# Altium schematic sheet FlashBoard.SchDoc
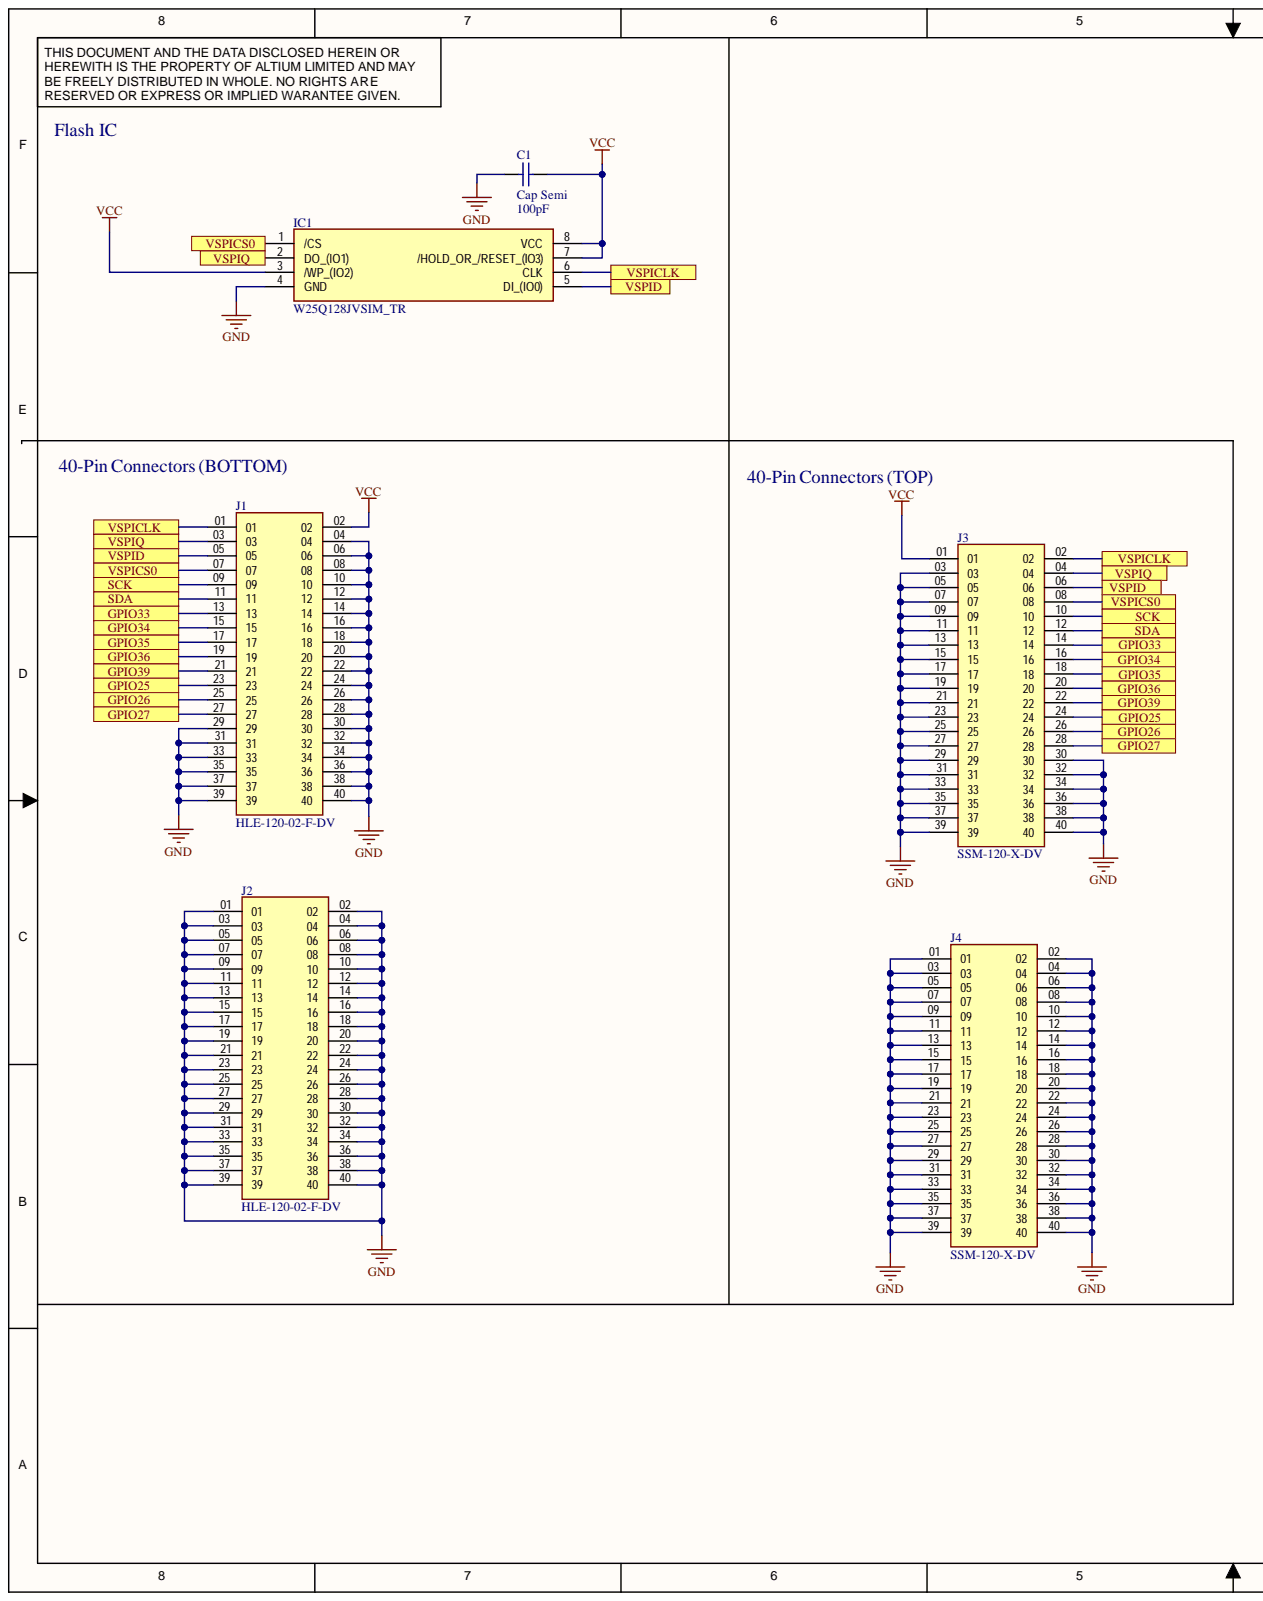
[diagram p0: FlashBoard.SchDoc, left]
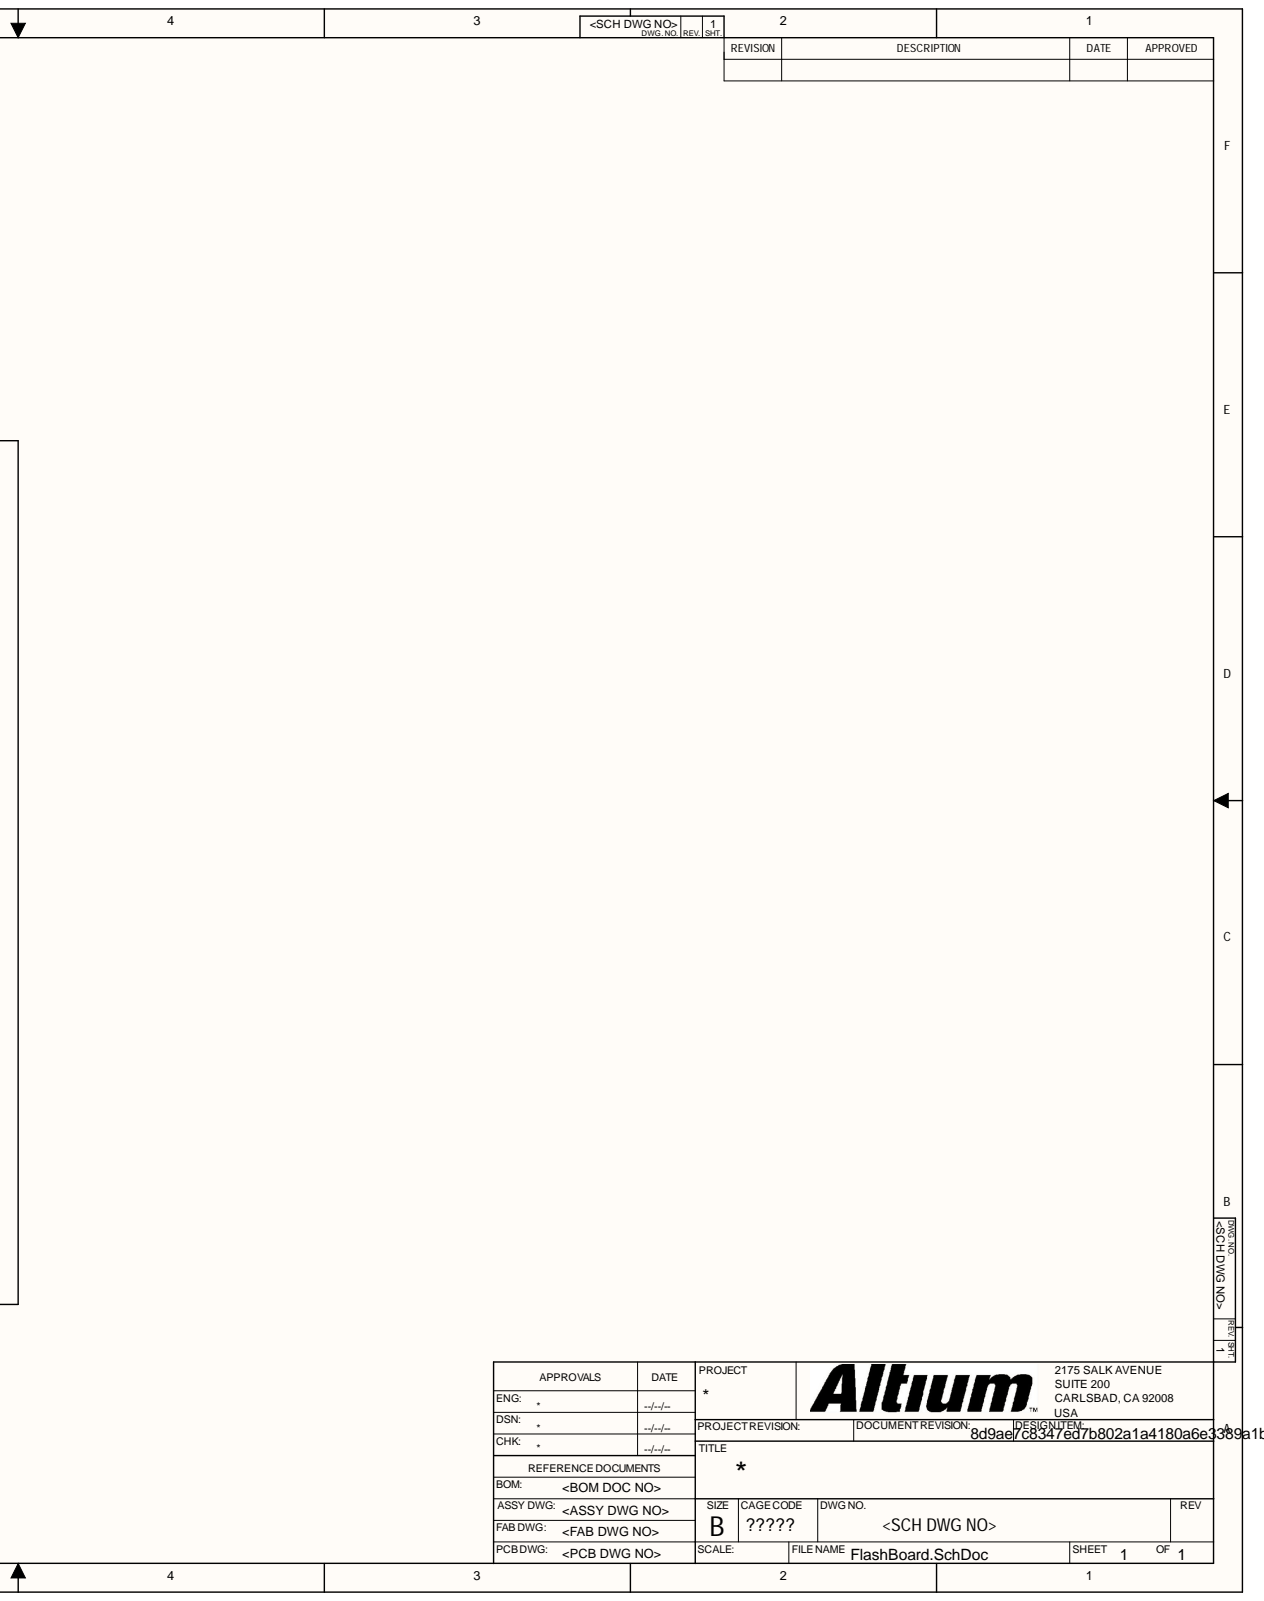
[diagram p1: FlashBoard.SchDoc, right]

## Parts
C1  Cap Semi  value 100pF  [C1206]
IC1  W25Q128JVSIM_TR  [SOIC127P790X216-8N]
J1  HLE-120-02-F-DV  [SAMTEC_HLE-120-02-F-DV]
J2  HLE-120-02-F-DV  [SAMTEC_HLE-120-02-F-DV]
J3  SSM-120-X-DV  [SAMTEC_SSM-120-X-DV]
J4  SSM-120-X-DV  [SAMTEC_SSM-120-X-DV]

## Ports
GPIO25, GPIO26, GPIO27, GPIO33, GPIO34, GPIO35, GPIO36, GPIO39, SCK, SDA, VSPICLK, VSPICS0, VSPID, VSPIQ

## Nets
GND: C1.1 IC1.4(GND) J1.04 J1.06 J1.08 J1.10 J1.12 J1.14 J1.16 J1.18 J1.20 J1.22 J1.24 J1.26 J1.28 J1.29 J1.30 J1.31 J1.32 J1.33 J1.34 J1.35 J1.36 J1.37 J1.38 J1.39 J1.40 J2.01 J2.02 J2.03 J2.04 J2.05 J2.06 J2.07 J2.08 J2.09 J2.10 J2.11 J2.12 J2.13 J2.14 J2.15 J2.16 J2.17 J2.18 J2.19 J2.20 J2.21 J2.22 J2.23 J2.24 J2.25 J2.26 J2.27 J2.28 J2.29 J2.30 J2.31 J2.32 J2.33 J2.34 J2.35 J2.36 J2.37 J2.38 J2.39 J2.40 J3.03 J3.05 J3.07 J3.09 J3.11 J3.13 J3.15 J3.17 J3.19 J3.21 J3.23 J3.25 J3.27 J3.29 J3.30 J3.31 J3.32 J3.33 J3.34 J3.35 J3.36 J3.37 J3.38 J3.39 J3.40 J4.01 J4.02 J4.03 J4.04 J4.05 J4.06 J4.07 J4.08 J4.09 J4.10 J4.11 J4.12 J4.13 J4.14 J4.15 J4.16 J4.17 J4.18 J4.19 J4.20 J4.21 J4.22 J4.23 J4.24 J4.25 J4.26 J4.27 J4.28 J4.29 J4.30 J4.31 J4.32 J4.33 J4.34 J4.35 J4.36 J4.37 J4.38 J4.39 J4.40
VCC: C1.2 IC1.3(/WP_(IO2)) IC1.7(/HOLD_OR_/RESET_(IO3)) IC1.8(VCC) J1.02 J3.01
GPIO25: J1.23 J3.24 ; port GPIO25
GPIO26: J1.25 J3.26 ; port GPIO26
GPIO27: J1.27 J3.28 ; port GPIO27
GPIO33: J1.13 J3.14 ; port GPIO33
GPIO34: J1.15 J3.16 ; port GPIO34
GPIO35: J1.17 J3.18 ; port GPIO35
GPIO36: J1.19 J3.20 ; port GPIO36
GPIO39: J1.21 J3.22 ; port GPIO39
SCK: J1.09 J3.10 ; port SCK
SDA: J1.11 J3.12 ; port SDA
VSPICLK: IC1.6(CLK) J1.01 J3.02 ; port VSPICLK
VSPICS0: IC1.1(/CS) J1.07 J3.08 ; port VSPICS0
VSPID: IC1.5(DI_(IO0)) J1.05 J3.06 ; port VSPID
VSPIQ: IC1.2(DO_(IO1)) J1.03 J3.04 ; port VSPIQ

## Library data of the parts
C1 = Cap Semi: Capacitor (Semiconductor SIM Model); Component Kind: Standard; LatestRevisionDate: 29-May-2009; LatestRevisionNote: IPC-7351 Footprint Added.; Library Name: Miscellaneous Devices.IntLib; PackageDescription: Chip Capacitor; PackageReference: C1206; Published: 8-Jun-2000; Signal Integrity: Cap Semi; Simulation: CAP; Publisher: Altium Limited
IC1 = W25Q128JVSIM_TR: Integrated Circuit; Manufacturer_Name: Winbond; Manufacturer_Part_Number: W25Q128JVSIM TR; Component Kind: Standard; Datasheet Link: https://componentsearchengine.com//W25Q128JVSIM TR.pdf; Height: 2.16mm; Library Name: SamacSys.SchLib; Mouser2 Part Number: 454-W25Q128JVSIMTR; Mouser2 Price/Stock: https://www.mouser.com/Search/Refine.aspx?Keyword=454-W25Q128JVSIMTR
J1 J2 = HLE-120-02-F-DV: 40 Position Receptacle, Bottom Entry Connector Surface Mount; MF: Samtec; Availability: In Stock; Check_prices: https://www.snapeda.com/parts/HLE-120-02-F-DV/Samtec+Inc./view-part/?ref=eda; Component Kind: Standard; Library Name: HLE-120-02-F-DV.IntLib; MP: HLE-120-02-F-DV; Package: None; Price: None; Purchase-URL: https://pricing.snapeda.com/search/part/HLE-120-02-F-DV/?ref=eda; SnapEDA_Link: https://www.snapeda.com/parts/HLE-120-02-F-DV/Samtec+Inc./view-part/?ref=snap
J3 J4 = SSM-120-X-DV: MF: Samtec; Availability: Unavailable; Component Kind: Standard; Library Name: SSM-120-X-DV.IntLib; MP: SSM-120-X-DV; Package: None; Price: None
